# Altium schematic sheet Microcontroller.SchDoc(Microcontroller)
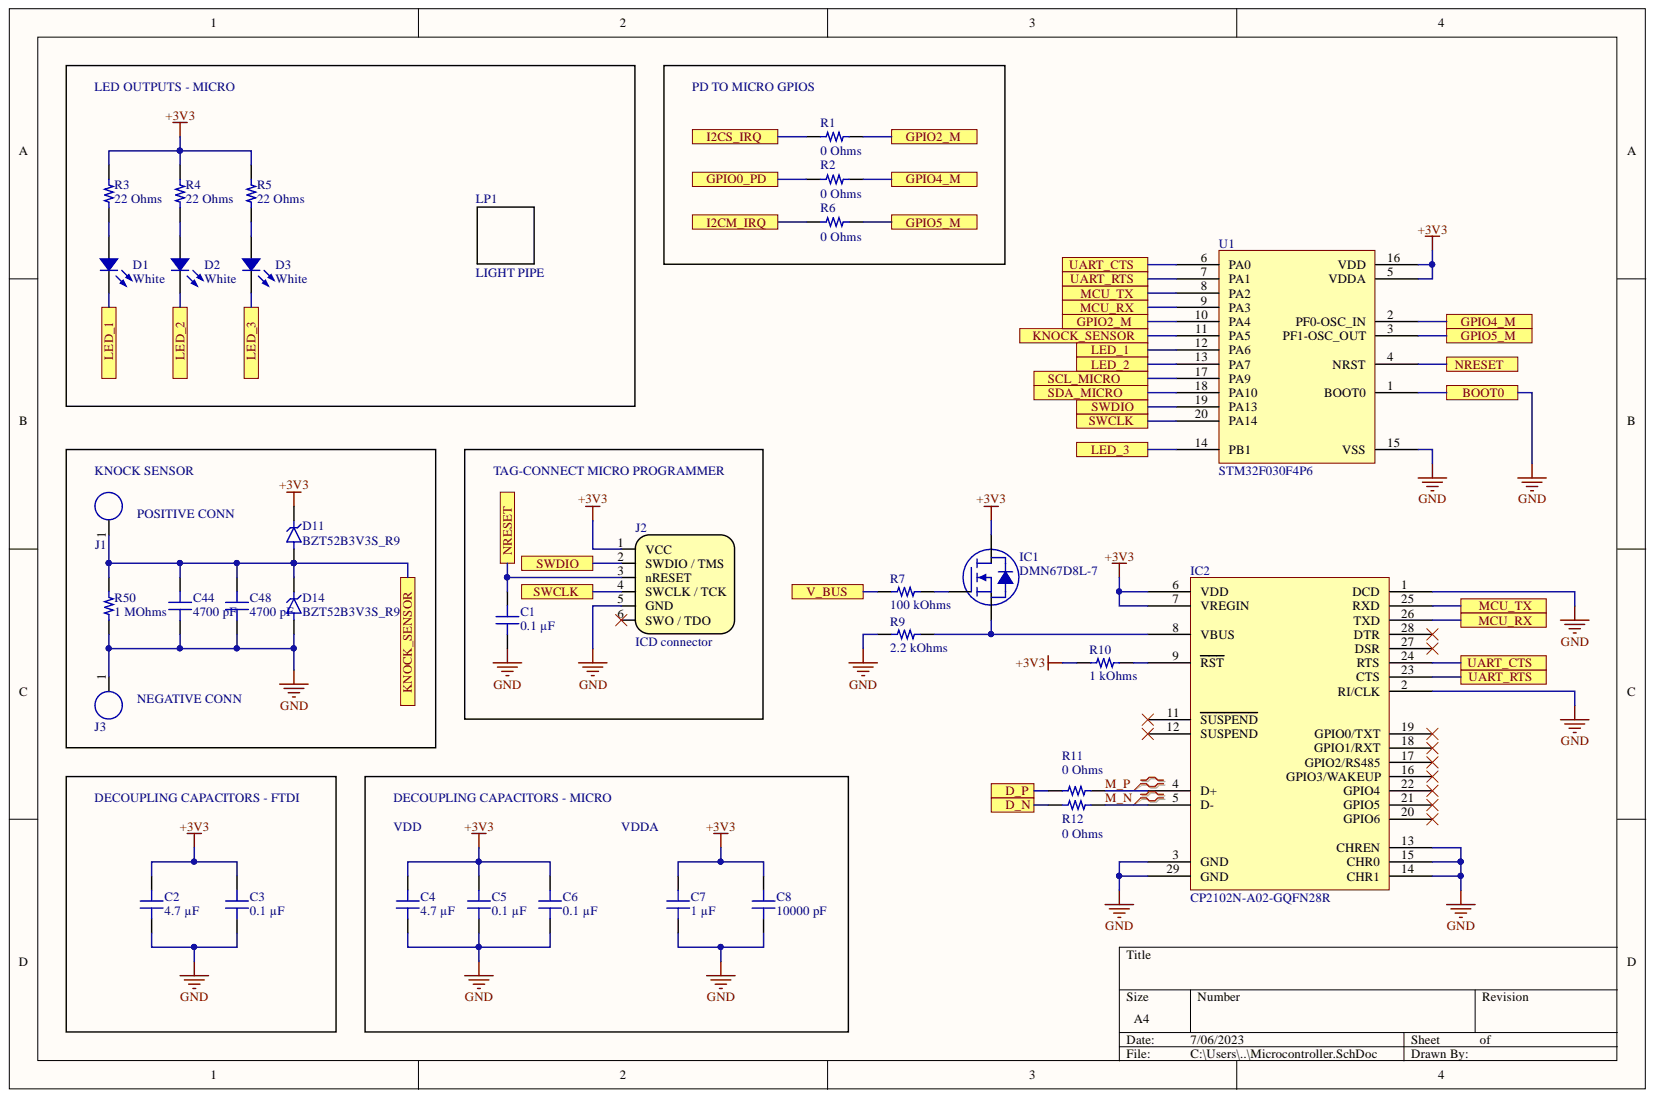
[diagram p0: Microcontroller.SchDoc]

## Parts
C1  0.1 µF  [CAP 0402_1005]
C2  4.7 µF  [CAP 0402_1005]
C3  0.1 µF  [CAP 0402_1005]
C4  4.7 µF  [CAP 0402_1005]
C5  0.1 µF  [CAP 0402_1005]
C6  0.1 µF  [CAP 0402_1005]
C7  1 µF  [CAP 0402_1005]
C8  10000 pF  [CAP 0402_1005]
C44  4700 pF  [CAP 0402_1005]
C48  4700 pF  [CAP 0402_1005]
D1  White  [LED 0805_2012]
D2  White  [LED 0805_2012]
D3  White  [LED 0805_2012]
D11  BZT52B3V3S_R9  [DIO_BZT52B3V3S_R9]
D14  BZT52B3V3S_R9  [DIO_BZT52B3V3S_R9]
IC1  DMN67D8L-7  [DIODES SOT-23-3]
IC2  CP2102N-A02-GQFN28R  [SILICON LABS QFN-28 5x5MM]
J1  Large Board Solder Point  [Large Solder Point]  (value not drawn)  (no BOM)
J2  ICD connector  [TC2030-MCP]  (no BOM)
J3  Large Board Solder Point  [Large Solder Point]  (value not drawn)  (no BOM)
LP1  LIGHT PIPE  [Dialight 515-1069F]
R1  0 Ohms  [RES 0402_1005]
R2  0 Ohms  [RES 0402_1005]
R3  22 Ohms  [RES 1206_3216]
R4  22 Ohms  [RES 1206_3216]
R5  22 Ohms  [RES 1206_3216]
R6  0 Ohms  [RES 0402_1005]
R7  100 kOhms  [RES 0603_1608]
R9  2.2 kOhms  [RES 0402_1005]
R10  1 kOhms  [RES 0402_1005]
R11  0 Ohms  [RES 0402_1005]
R12  0 Ohms  [RES 0402_1005]
R50  1 MOhms  [RES 0603_1608]
U1  STM32F030F4P6  [TSOP65P640X120-20N]

## Ports
BOOT0, D_N, D_P, GPIO0_PD, GPIO2_M, GPIO4_M, GPIO5_M, I2CM_IRQ, I2CS_IRQ, KNOCK_SENSOR, LED_1, LED_2, LED_3, MCU_RX, MCU_TX, NRESET, SCL_MICRO, SDA_MICRO, SWCLK, SWDIO, UART_CTS, UART_RTS, V_BUS

## Nets
+3V3: C2.2 C3.2 C4.2 C5.2 C6.2 C7.2 C8.2 D11.C IC1.3 IC2.6(VDD) IC2.7(VREGIN) J2.1(VCC) R3.2 R4.2 R5.2 R10.1 U1.5(VDDA) U1.16(VDD)
D_N: R12.1 ; port D_N
D_P: R11.1 ; port D_P
GND: C1.1 C2.1 C3.1 C4.1 C5.1 C6.1 C7.1 C8.1 C44.1 C48.1 D14.A IC2.1(DCD) IC2.2(RI/CLK) IC2.3(GND) IC2.13(CHREN) IC2.14(CHR1) IC2.15(CHR0) IC2.29(GND) J2.5(GND) J3.1 R9.1 R50.1 U1.1(BOOT0) U1.15(VSS) ; port BOOT0
GPIO0_PD: R2.1 ; port GPIO0_PD
GPIO2_M: R1.2 U1.10(PA4) ; port GPIO2_M
GPIO4_M: R2.2 U1.2(PF0-OSC_IN) ; port GPIO4_M
GPIO5_M: R6.2 U1.3(PF1-OSC_OUT) ; port GPIO5_M
I2CM_IRQ: R6.1 ; port I2CM_IRQ
I2CS_IRQ: R1.1 ; port I2CS_IRQ
KNOCK_SENSOR: C44.2 C48.2 D11.A D14.C J1.1 R50.2 U1.11(PA5) ; port KNOCK_SENSOR
LED_1: D1.2 U1.12(PA6) ; port LED_1
LED_2: D2.2 U1.13(PA7) ; port LED_2
LED_3: D3.2 U1.14(PB1) ; port LED_3
M_N: IC2.5(D-) R12.2
M_P: IC2.4(D+) R11.2
MCU_RX: IC2.26(TXD) U1.9(PA3) ; port MCU_RX
MCU_TX: IC2.25(RXD) U1.8(PA2) ; port MCU_TX
NRESET: C1.2 J2.3(nRESET) U1.4(NRST) ; port NRESET
SCL_MICRO: U1.17(PA9) ; port SCL_MICRO
SDA_MICRO: U1.18(PA10) ; port SDA_MICRO
SWCLK: J2.4(SWCLK_/_TCK) U1.20(PA14) ; port SWCLK
SWDIO: J2.2(SWDIO_/_TMS) U1.19(PA13) ; port SWDIO
UART_CTS: IC2.24(RTS) U1.6(PA0) ; port UART_CTS
UART_RTS: IC2.23(CTS) U1.7(PA1) ; port UART_RTS
V_BUS: R7.1 ; port V_BUS
NetD1_1: D1.1 R3.1
NetD2_1: D2.1 R4.1
NetD3_1: D3.1 R5.1
NetIC1_1: IC1.1 R7.2
NetIC1_2: IC1.2 IC2.8(VBUS) R9.2
NetIC2_9: IC2.9(RST) R10.2

Not connected: IC2 11(SUSPEND) 12(SUSPEND) 16(GPIO3/WAKEUP) 17(GPIO2/RS485) 18(GPIO1/RXT) 19(GPIO0/TXT) 20(GPIO6) 21(GPIO5) 22(GPIO4) 27(DSR) 28(DTR); J2 6(SWO_/_TDO)

## Library data of the parts
C1 C3 C5 C6 = LMK105BJ104MC-F: CAP CER 0.1UF 10V X5R 0402; manufacturer: Taiyo Yuden; Tolerance: ±20%; Database Table Name: Capacitors - Ceramic - 0402; Voltage - Rated: 10V; Value: 0.1 µF; Thickness (Max): 0.009" (0.22mm); Temperature Coefficient: X5R; Supplier Part Number 1: 587-4572-1-ND; Supplier 1: DigiKey; Size / Dimension: 0.039" L x 0.020" W (1.00mm x 0.50mm); series: M; price: 0.03; Part Status: Active; Part Number: LMK105BJ104MC-F; partid: 2414; packaging: TapeAndReel; Package / Case: 0402 (1005 Metric); Operating Temperature: -55°C ~ 85°C; Mounting Type: Surface Mount, MLCC; Minimum Order: 1; Lifecycle Status: Active; Library Name: Celestial Altium Library - altium_library.DbLib; lastupdated: 9/08/2022 12:01:16 PM; Device Package: 0402 (1005 Metric); componentlink2url: https://www.digikey.com/en/products/detail/taiyo-yuden/LMK105BJ104MC-F/6035743; componentlink2description: DigiKey Link; componentlink1url: https://www.yuden.co.jp/productdata/catalog/mlcc01_e.pdf; componentlink1description: Datasheet; Component Kind: Standard; Capacitance: 0.1 µF; Applications: SMPS Filtering
C2 C4 = CL05A475KP5NRNC: CAP CER 4.7UF 10V X5R 0402; manufacturer: Samsung Electro-Mechanics; Tolerance: ±10%; Database Table Name: Capacitors - Ceramic - 0402; Voltage - Rated: 10V; Value: 4.7 µF; Thickness (Max): 0.022" (0.55mm); Temperature Coefficient: X5R; Supplier Part Number 1: 1276-1480-1-ND; Supplier 1: DigiKey; Size / Dimension: 0.039" L x 0.020" W (1.00mm x 0.50mm); series: CL; price: 0.04; Part Status: Active; Part Number: CL05A475KP5NRNC; partid: 2191; packaging: TapeAndReel; Package / Case: 0402 (1005 Metric); Operating Temperature: -55°C ~ 85°C; Mounting Type: Surface Mount, MLCC; Minimum Order: 1; Lifecycle Status: Active; Library Name: Celestial Altium Library - altium_library.DbLib; lastupdated: 9/08/2022 12:01:16 PM; Device Package: 0402 (1005 Metric); componentlink2url: https://www.digikey.com/en/products/detail/samsung-electro-mechanics/CL05A475KP5NRNC/3887138; componentlink2description: DigiKey Link; componentlink1url: http://www.samsungsem.com/kr/support/product-search/mlcc/CL05A475KP5NRNC.jsp; componentlink1description: Datasheet; Component Kind: Standard; Capacitance: 4.7 µF; Applications: General Purpose
C7 = LMK105BJ105KPLF: CAP CER 1UF 10V X5R 0402; manufacturer: Taiyo Yuden; Tolerance: ±10%; Database Table Name: Capacitors - Ceramic - 0402; Voltage - Rated: 10V; Value: 1 µF; Thickness (Max): 0.026" (0.65mm); Temperature Coefficient: X5R; Supplier Part Number 1: 587-6274-1-ND; Supplier 1: DigiKey; Size / Dimension: 0.039" L x 0.020" W (1.00mm x 0.50mm); series: M; price: 0.02; Part Status: Active; Part Number: LMK105BJ105KPLF; partid: 2328; packaging: TapeAndReel; Package / Case: 0402 (1005 Metric); Operating Temperature: -55°C ~ 85°C; Mounting Type: Surface Mount, MLCC; Minimum Order: 1; Lifecycle Status: Active; Library Name: Celestial Altium Library - altium_library.DbLib; lastupdated: 9/08/2022 12:01:16 PM; Device Package: 0402 (1005 Metric); componentlink2url: https://www.digikey.com/en/products/detail/taiyo-yuden/LMK105BJ105KPLF/7403744; componentlink2description: DigiKey Link; componentlink1url: https://ds.yuden.co.jp/TYCOMPAS/ut/detail?pn=LMK105BJ105KPLF &u=M; componentlink1description: Datasheet; Component Kind: Standard; Capacitance: 1 µF; Applications: SMPS Filtering
C8 = LMF105B7103KVHF: CAP, MLCC, 0402/1005, 10V, X7R,; manufacturer: Taiyo Yuden; Tolerance: ±10%; Database Table Name: Capacitors - Ceramic - 0402; Voltage - Rated: 10V; Value: 10000 pF; Thickness (Max): 0.022" (0.55mm); Temperature Coefficient: X7R; Supplier Part Number 1: 587-6579-1-ND; Supplier 1: DigiKey; Size / Dimension: 0.039" L x 0.020" W (1.00mm x 0.50mm); series: M; Ratings: AEC-Q200; price: 0.01; Part Status: Active; Part Number: LMF105B7103KVHF; partid: 1869; packaging: TapeAndReel; Package / Case: 0402 (1005 Metric); Operating Temperature: -55°C ~ 125°C; Mounting Type: Surface Mount, MLCC; Minimum Order: 1; Lifecycle Status: Active; Library Name: Celestial Altium Library - altium_library.DbLib; lastupdated: 9/08/2022 12:01:17 PM; Device Package: 0402 (1005 Metric); componentlink2url: https://www.digikey.com/en/products/detail/taiyo-yuden/LMF105B7103KVHF/10379145; componentlink2description: DigiKey Link; componentlink1url: https://ds.yuden.co.jp/TYCOMPAS/ut/detail?pn=LMF105B7103KVHF&u=M; componentlink1description: Datasheet; Component Kind: Standard; Capacitance: 10000 pF; Applications: Automotive, SMPS Filtering
C44 C48 = 0402B472J500CT: CAP CER 4700PF 50V X7R 0402; manufacturer: Walsin Technology Corporation; Tolerance: ±5%; Database Table Name: Capacitors - Ceramic - 0402; Voltage - Rated: 50V; Value: 4700 pF; Thickness (Max): 0.022" (0.55mm); Temperature Coefficient: X7R; Supplier Part Number 1: 1292-1210-1-ND; Supplier 1: DigiKey; Size / Dimension: 0.039" L x 0.020" W (1.00mm x 0.50mm); series: -; price: 0.01; Part Status: Active; Part Number: 0402B472J500CT; partid: 1879; packaging: TapeAndReel; Package / Case: 0402 (1005 Metric); Operating Temperature: -55°C ~ 125°C; Mounting Type: Surface Mount, MLCC; Minimum Order: 1; Lifecycle Status: Active; Library Name: Celestial Altium Library - altium_library.DbLib; lastupdated: 11/05/2023 7:06:21 PM; Device Package: 0402 (1005 Metric); componentlink2url: https://www.digikey.com/en/products/detail/walsin-technology-corporation/0402B472J500CT/9354708; componentlink2description: DigiKey Link; componentlink1url: http://www.passivecomponent.com/wp-content/uploads/2018/10/MLCC.pdf; componentlink1description: Datasheet; Component Kind: Standard; Capacitance: 4700 pF; Applications: General Purpose
D1-D3 = QBLP631-IW5: 0805 LED WHITE, YELLOW DIFFUSED; Manufacturer: QT Brightek (QTB); Voltage - Forward (Vf) (Typ): 2.9V; Viewing Angle: 140°; Millicandela Rating: 85mcd; Lens Style: Rectangle with Flat Top; Lens Size: 1.30mm x 1.25mm; Database Table Name: LED - Indication; Current - Test: 5mA; Configuration: Standard; Value: White; Supplier Part Number 1: 1516-QBLP631-IW5CT-ND; Supplier Device Package: 0805; Supplier 1: DigiKey; Size / Dimension: 2.00mm L x 1.25mm W; Series: QBLP631; Price: 0.08; Part Status: Active; Part Number: QBLP631-IW5; PartId: 1097; Packaging: TapeAndReel; Package / Case: 0805 (2012 Metric); Mounting Type: Surface Mount; Minimum Order: 1; Lifecycle Status: Active; Library Name: Celestial Altium Library - altium_library.DbLib; Lens Transparency: Diffused; Lens Color: Yellow; LastUpdated: 9/08/2022 12:43:05 PM; Height (Max): 0.90mm; Device Package: 0805 (2012 Metric); ComponentLink2URL: https://www.digikey.com/en/products/detail/qt-brightek-qtb/QBLP631-IW5/16499651; ComponentLink2Description: DigiKey Link; ComponentLink1URL: https://www.qt-brightek.com/datasheet/QBLP631-IW5.pdf; ComponentLink1Description: Datasheet; Component Kind: Standard; Color: White
D11 D14 = BZT52B3V3S_R9: MF: {'manufacturer': u'Taiwan Semiconductor'}; Price: None; Package: None; MP: BZT52B3V3S_R9; Component Kind: Standard; Availability: Unavailable
IC1 = DMN67D8L-7: MOSFET N-CH 60V 210MA SOT23; Manufacturer: Diodes Incorporated; Vgs(th) (Max) @ Id: 2.5V @ 250µA; Vgs (Max): ±30V; Rds On (Max) @ Id, Vgs: 5Ohm @ 500mA, 10V; Power Dissipation (Max): 340mW (Ta); Input Capacitance (Ciss) (Max) @ Vds: 22 pF @ 25 V; Gate Charge (Qg) (Max) @ Vgs: 0.82 nC @ 10 V; FET Type: N-Channel; Drive Voltage (Max Rds On,  Min Rds On): 5V, 10V; Database Table Name: MOSFET - Single - N-Ch; Current - Continuous Drain (Id) @ 25°C: 210mA (Ta); Technology: MOSFET (Metal Oxide); Supplier Part Number 1: DMN67D8L-7DICT-ND; Supplier Device Package: SOT-23-3; Supplier 1: DigiKey; Series: -; Price: 0.03; Part Status: Active; Part Number: DMN67D8L-7; Packaging: TapeAndReel; Package / Case: TO-236-3, SC-59, SOT-23-3; Operating Temperature: -55°C ~ 150°C (TJ); Mounting Type: Surface Mount; Minimum Order: 1; Lifecycle Status: Active; Library Name: Celestial Altium Library - altium_library.DbLib; LastUpdated: 9/08/2022 12:48:06 PM; Drain to Source Voltage (Vdss): 60 V; ComponentLink2URL: https://www.digikey.com/en/products/detail/diodes-incorporated/DMN67D8L-7/5768832; ComponentLink2Description: DigiKey Link; ComponentLink1URL: https://www.diodes.com/assets/Datasheets/DMN67D8L.pdf; ComponentLink1Description: Datasheet; Component Kind: Standard
IC2 = CP2102N-A02-GQFN28R: IC USB TO UART BRIDGE QFN28; Manufacturer: Silicon Labs; Voltage - Supply: 3V ~ 3.6V; Standards: USB 2.0; Function: Bridge, USB to UART; Database Table Name: Interface - Controllers; Current - Supply: 9.5mA; Supplier Part Number 1: 336-5890-1-ND; Supplier Device Package: 28-QFN (5x5); Supplier 1: DigiKey; Series: USBXpress™; Protocol: USB; Price: 1.23; Part Status: Active; Part Number: CP2102N-A02-GQFN28R; Packaging: TapeAndReel; Package / Case: 28-WFQFN Exposed Pad; Operating Temperature: -40°C ~ 85°C (TA); Minimum Order: 1; Lifecycle Status: Active; Library Name: Celestial Altium Library - altium_library.DbLib; LastUpdated: 31/07/2020 4:26:30 PM; Interface: UART; ComponentLink2URL: http://digikey.com/product-detail/en/silicon-labs/CP2102N-A02-GQFN28R/336-5890-1-ND/9863483; ComponentLink2Description: DigiKey Link; ComponentLink1URL: https://www.silabs.com/documents/public/data-sheets/cp2102n-datasheet.pdf; ComponentLink1Description: Datasheet; Component Kind: Standard
J2 = TC2030-MCP-NL: Conector par ICD; Library Name: TC2030.SchLib
LP1 = Dialight 515-1069F: Light Pipe Dialight 515-1069F; Library Name: Schlib2.SchLib; Component Kind: Standard
R1 R2 R6 R11 R12 = CRCW04020000Z0EDHP: RES SMD 0 OHM JUMPER 1/5W 0402; Manufacturer: Vishay Dale; Power (Watts): 0.2W, 1/5W; Database Table Name: Resistors - Surface Mount - 0402; Value: 0 Ohms; Tolerance: Jumper; Supplier Part Number 1: 541-0.0YBCT-ND; Supplier Device Package: 0402; Supplier 1: DigiKey; Size / Dimension: 0.039" L x 0.020" W (1.00mm x 0.50mm); Series: CRCW-HP; Resistance: 0 Ohms; Ratings: AEC-Q200; Price: 0.01; Part Status: Active; Part Number: CRCW04020000Z0EDHP; PartId: 3131; Packaging: TapeAndReel; Package / Case: 0402 (1005 Metric); Operating Temperature: -55°C ~ 155°C; Number of Terminations: 2; Minimum Order: 1; Lifecycle Status: Active; Library Name: Celestial Altium Library - altium_library.DbLib; LastUpdated: 27/04/2023 7:26:03 PM; Height - Seated (Max): 0.016" (0.40mm); Features: Automotive AEC-Q200, Pulse Withstanding; Device Package: 0402 (1005 Metric); Composition: Thick Film; ComponentLink2URL: https://www.digikey.com/en/products/detail/vishay-dale/CRCW04020000Z0EDHP/2222496; ComponentLink2Description: DigiKey Link; ComponentLink1URL: http://www.vishay.com/docs/20043/crcwhpe3.pdf; ComponentLink1Description: Datasheet; Component Kind: Standard
R3-R5 = HRG3216Q-22R0-D-T1: RES SMD 22 OHM 0.5% 1W 1206; Manufacturer: Susumu; Temperature Coefficient: ±50ppm/°C; Database Table Name: Resistors - Surface Mount - 1206; Value: 22 Ohms; Tolerance: ±0.5%; Supplier Part Number 1: 408-1897-1-ND; Supplier Device Package: 1206; Supplier 1: DigiKey; Size / Dimension: 0.126" L x 0.065" W (3.20mm x 1.65mm); Series: HRG; Resistance: 22 Ohms; Ratings: AEC-Q200; Price: 0.30; Power (Watts): 1W; Part Status: Active; Part Number: HRG3216Q-22R0-D-T1; PartId: 35615; Packaging: TapeAndReel; Package / Case: 1206 (3216 Metric); Operating Temperature: -55°C ~ 155°C; Number of Terminations: 2; Minimum Order: 1; Lifecycle Status: Active; Library Name: Celestial Altium Library - altium_library.DbLib; LastUpdated: 19/09/2022 1:29:16 PM; Height - Seated (Max): 0.022" (0.55mm); Features: Anti-Sulfur, Automotive AEC-Q200, Moisture Resistant; Device Package: 1206 (3216 Metric); Composition: Thin Film; ComponentLink2URL: https://www.digikey.com/en/products/detail/susumu/HRG3216Q-22R0-D-T1/5762558; ComponentLink2Description: DigiKey Link; ComponentLink1URL: http://www.susumu.co.jp/common/pdf/n_catalog_partition24_en.pdf; ComponentLink1Description: Datasheet; Component Kind: Standard
R7 = RNCS0603BKE100K: RES 100K OHM 0.1% 1/16W 0603; Manufacturer: Stackpole Electronics Inc; Temperature Coefficient: ±25ppm/°C; Power (Watts): 0.063W, 1/16W; Database Table Name: Resistors - Surface Mount - 0603; Value: 100 kOhms; Tolerance: ±0.1%; Supplier Part Number 1: RNCS0603BKE100KCT-ND; Supplier Device Package: 0603; Supplier 1: DigiKey; Size / Dimension: 0.061" L x 0.031" W (1.55mm x 0.80mm); Series: RNCS; Resistance: 100 kOhms; Ratings: AEC-Q200; Price: 0.08; Part Status: Active; Part Number: RNCS0603BKE100K; PartId: 4849; Packaging: TapeAndReel; Package / Case: 0603 (1608 Metric); Operating Temperature: -55°C ~ 155°C; Number of Terminations: 2; Minimum Order: 1; Lifecycle Status: Active; Library Name: Celestial Altium Library - altium_library.DbLib; LastUpdated: 19/09/2022 1:22:34 PM; Height - Seated (Max): 0.022" (0.55mm); Features: Anti-Corrosive, Automotive AEC-Q200, Moisture Resistant; Device Package: 0603 (1608 Metric); Composition: Thin Film; ComponentLink2URL: https://www.digikey.com/en/products/detail/stackpole-electronics-inc/RNCS0603BKE100K/1708835; ComponentLink2Description: DigiKey Link; ComponentLink1URL: https://www.seielect.com/catalog/sei-rncs_rnch.pdf; ComponentLink1Description: Datasheet; Component Kind: Standard
R9 = TNPW04022K20BYEP: RES 2.2K OHM 0.1% 1/10W 0402; Manufacturer: Vishay Dale; Temperature Coefficient: ±10ppm/°C; Power (Watts): 0.1W, 1/10W; Database Table Name: Resistors - Surface Mount - 0402; Value: 2.2 kOhms; Tolerance: ±0.1%; Supplier Part Number 1: 541-9805-1-ND; Supplier Device Package: 0402; Supplier 1: DigiKey; Size / Dimension: 0.039" L x 0.020" W (1.00mm x 0.50mm); Series: TNPW e3; Resistance: 2.2 kOhms; Ratings: AEC-Q200; Price: 0.28; Part Status: Active; Part Number: TNPW04022K20BYEP; PartId: 3077; Packaging: TapeAndReel; Package / Case: 0402 (1005 Metric); Operating Temperature: -55°C ~ 155°C; Number of Terminations: 2; Minimum Order: 1; Lifecycle Status: Active; Library Name: Celestial Altium Library - altium_library.DbLib; LastUpdated: 9/08/2022 12:11:02 PM; Height - Seated (Max): 0.016" (0.40mm); Features: Anti-Sulfur, Automotive AEC-Q200, Moisture Resistant; Device Package: 0402 (1005 Metric); Composition: Thin Film; ComponentLink2URL: https://www.digikey.com/en/products/detail/vishay-dale/TNPW04022K20BYEP/8636618; ComponentLink2Description: DigiKey Link; ComponentLink1URL: http://www.vishay.com/docs/28758/tnpw_e3.pdf; ComponentLink1Description: Datasheet; Component Kind: Standard
R10 = AF0402JR-071KL: RES SMD 1K OHM 5% 1/16W 0402; Manufacturer: Yageo; Temperature Coefficient: ±100ppm/°C; Power (Watts): 0.063W, 1/16W; Database Table Name: Resistors - Surface Mount - 0402; Value: 1 kOhms; Tolerance: ±5%; Supplier Part Number 1: 13-AF0402JR-071KLCT-ND; Supplier Device Package: 0402; Supplier 1: DigiKey; Size / Dimension: 0.039" L x 0.020" W (1.00mm x 0.50mm); Series: AF; Resistance: 1 kOhms; Ratings: AEC-Q200; Price: 0.01; Part Status: Active; Part Number: AF0402JR-071KL; PartId: 68908; Packaging: CutTape; Package / Case: 0402 (1005 Metric); Operating Temperature: -55°C ~ 155°C; Number of Terminations: 2; Minimum Order: 1; Lifecycle Status: Active; Library Name: Celestial Altium Library - altium_library.DbLib; LastUpdated: 9/08/2022 12:09:39 PM; Height - Seated (Max): 0.015" (0.37mm); Features: Anti-Sulfur, Automotive AEC-Q200; Device Package: 0402 (1005 Metric); Composition: Thick Film; ComponentLink2URL: https://www.digikey.com/en/products/detail/yageo/AF0402JR-071KL/5900732; ComponentLink2Description: DigiKey Link; ComponentLink1URL: https://www.yageo.com/upload/media/product/productsearch/datasheet/rchip/PYu-AF_51_RoHS_L_6.pdf; ComponentLink1Description: Datasheet; Component Kind: Standard
R50 = RT0603BRD071ML: RES SMD 1M OHM 0.1% 1/10W 0603; Manufacturer: Yageo; Temperature Coefficient: ±25ppm/°C; Power (Watts): 0.1W, 1/10W; Database Table Name: Resistors - Surface Mount - 0603; Value: 1 MOhms; Tolerance: ±0.1%; Supplier Part Number 1: YAG4498CT-ND; Supplier Device Package: 0603; Supplier 1: DigiKey; Size / Dimension: 0.063" L x 0.031" W (1.60mm x 0.80mm); Series: RT; Resistance: 1 MOhms; Price: 0.03; Part Status: Active; Part Number: RT0603BRD071ML; PartId: 5503; Packaging: TapeAndReel; Package / Case: 0603 (1608 Metric); Operating Temperature: -55°C ~ 155°C; Number of Terminations: 2; Minimum Order: 1; Lifecycle Status: Active; Library Name: Celestial Altium Library - altium_library.DbLib; LastUpdated: 10/05/2023 7:38:03 PM; Height - Seated (Max): 0.022" (0.55mm); Device Package: 0603 (1608 Metric); Composition: Thin Film; ComponentLink2URL: https://www.digikey.com/en/products/detail/yageo/RT0603BRD071ML/5927906; ComponentLink2Description: DigiKey Link; ComponentLink1URL: https://www.yageo.com/upload/media/product/productsearch/datasheet/rchip/PYu-RT_1-to-0.01_RoHS_L_11.pdf; ComponentLink1Description: Datasheet; Component Kind: Standard
U1 = STM32F030F4P6: Value-line Arm-based 32-bit MCU with up to 256 KB Flash, timers, ADC, communication interfaces, 2.4-3.6 V operation - TSSOP20 20-pin; Library Name: Schlib2.SchLib; Component Kind: Standard
J1 J3 = Large Board Solder Point: Library Name: Schlib2.SchLib; Description: Large Board Solder Point
